# Altium schematic sheet 05_BANK_DDR3.SchDoc
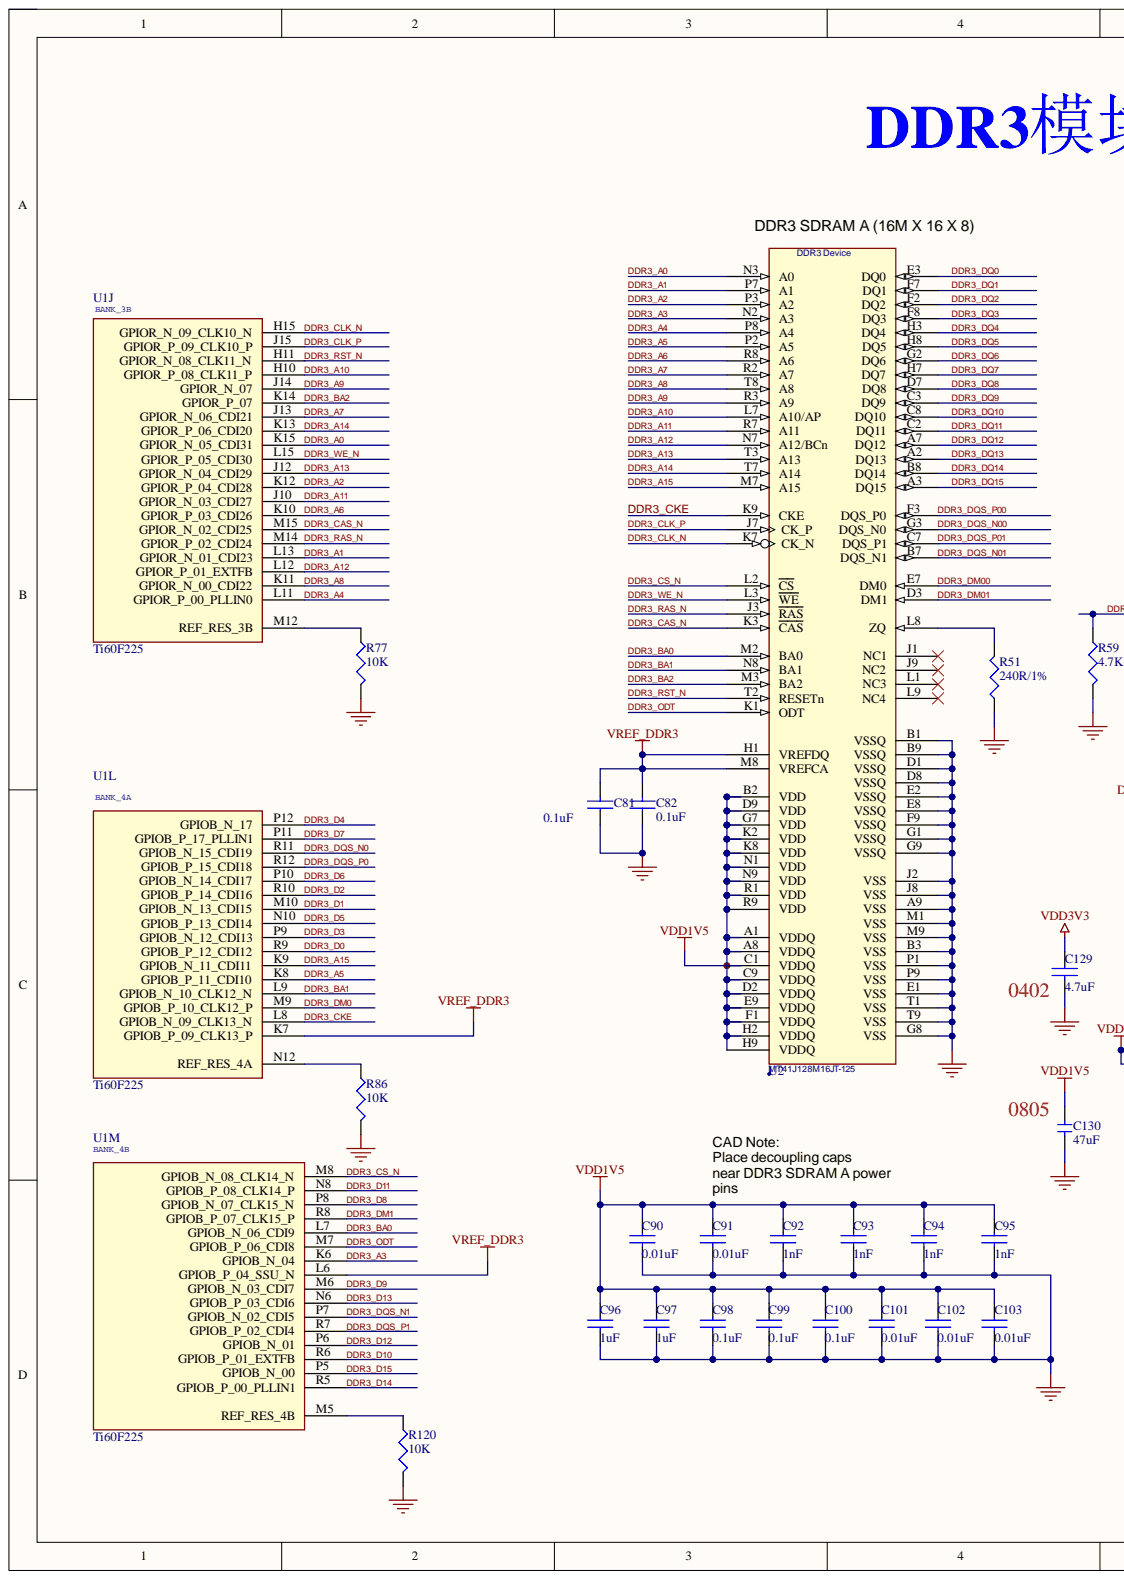
[diagram p0: 05_BANK_DDR3.SchDoc, left]
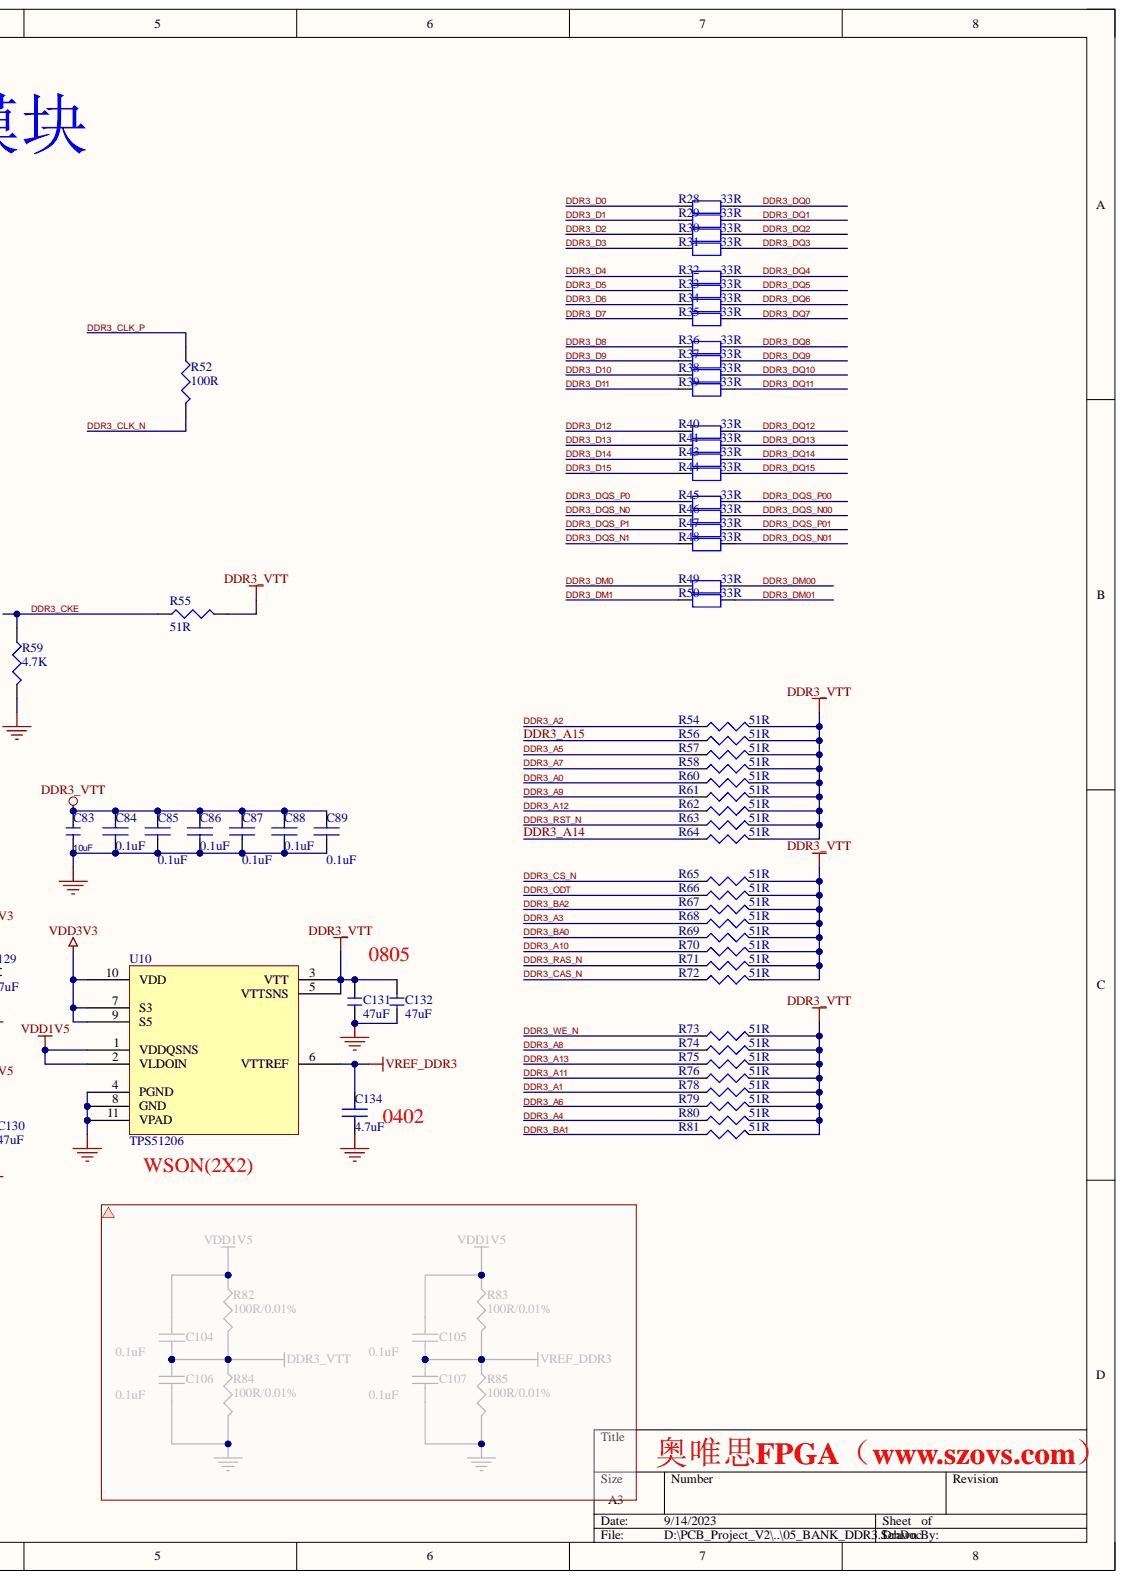
[diagram p1: 05_BANK_DDR3.SchDoc, right]

## Parts
C81  0.1uF  [R0402]
C82  0.1uF  [R0402]
C83  10uF  [R0603]
C84  0.1uF  [R0402]
C85  0.1uF  [R0402]
C86  0.1uF  [R0402]
C87  0.1uF  [R0402]
C88  0.1uF  [R0402]
C89  0.1uF  [R0402]
C90  0.01uF  [R0402]
C91  0.01uF  [R0402]
C92  1nF  [R0402]
C93  1nF  [R0402]
C94  1nF  [R0402]
C95  1nF  [R0402]
C96  1uF  [R0402]
C97  1uF  [R0402]
C98  0.1uF  [R0402]
C99  0.1uF  [R0402]
C100  0.1uF  [R0402]
C101  0.01uF  [R0402]
C102  0.01uF  [R0402]
C103  0.01uF  [R0402]
C129  4.7uF  [R0402]
C130  47uF  [R0805]
C131  47uF  [R0805]
C132  47uF  [R0805]
C134  4.7uF  [R0402]
R28  33R  [R0402]
R29  33R  [R0402]
R30  33R  [R0402]
R31  33R  [R0402]
R32  33R  [R0402]
R33  33R  [R0402]
R34  33R  [R0402]
R35  33R  [R0402]
R36  33R  [R0402]
R37  33R  [R0402]
R38  33R  [R0402]
R39  33R  [R0402]
R40  33R  [R0402]
R41  33R  [R0402]
R43  33R  [R0402]
R44  33R  [R0402]
R45  33R  [R0402]
R46  33R  [R0402]
R47  33R  [R0402]
R48  33R  [R0402]
R49  33R  [R0402]
R50  33R  [R0402]
R51  240R/1%  [R0402]
R52  100R  [R0402]
R54  51R  [R0402]
R55  51R  [R0402]
R56  51R  [R0402]
R57  51R  [R0402]
R58  51R  [R0402]
R59  4.7K  [R0402]
R60  51R  [R0402]
R61  51R  [R0402]
R62  51R  [R0402]
R63  51R  [R0402]
R64  51R  [R0402]
R65  51R  [R0402]
R66  51R  [R0402]
R67  51R  [R0402]
R68  51R  [R0402]
R69  51R  [R0402]
R70  51R  [R0402]
R71  51R  [R0402]
R72  51R  [R0402]
R73  51R  [R0402]
R74  51R  [R0402]
R75  51R  [R0402]
R76  51R  [R0402]
R77  10K  [R0402]
R78  51R  [R0402]
R79  51R  [R0402]
R80  51R  [R0402]
R81  51R  [R0402]
R86  10K  [R0402]
R120  10K  [R0402]
U1  Ti60F225  [ti60F225]  (parts J,L,M)
U2  MT41J128M16JT-125  [FBGA-96_L13.5-W7.5]
U10  TPS51206  [WSON10-040-0202MM]

## Nets
DDR3_VTT: C83.1 C84.1 C85.1 C86.1 C87.1 C88.1 C89.1 C131.1 C132.1 R54.2 R55.2 R56.2 R57.2 R58.2 R60.2 R61.2 R62.2 R63.2 R64.2 R65.2 R66.2 R67.2 R68.2 R69.2 R70.2 R71.2 R72.2 R73.2 R74.2 R75.2 R76.2 R78.2 R79.2 R80.2 R81.2 U10.3(VTT) U10.5(VTTSNS)
GND: C81.1 C82.1 C83.2 C84.2 C85.2 C86.2 C87.2 C88.2 C89.2 C90.2 C91.2 C92.2 C93.2 C94.2 C95.2 C96.2 C97.2 C98.2 C99.2 C100.2 C101.2 C102.2 C103.2 C129.2 C130.2 C131.2 C132.2 C134.2 R51.1 R59.2 R77.2 R86.2 R120.2 U2.A9(VSS) U2.B1(VSSQ) U2.B3(VSS) U2.B9(VSSQ) U2.D1(VSSQ) U2.D8(VSSQ) U2.E1(VSS) U2.E2(VSSQ) U2.E8(VSSQ) U2.F9(VSSQ) U2.G1(VSSQ) U2.G8(VSS) U2.G9(VSSQ) U2.J2(VSS) U2.J8(VSS) U2.M1(VSS) U2.M9(VSS) U2.P1(VSS) U2.P9(VSS) U2.T1(VSS) U2.T9(VSS) U10.4(PGND) U10.8(GND) U10.11(VPAD)
VDD1V5: C90.1 C91.1 C92.1 C93.1 C94.1 C95.1 C96.1 C97.1 C98.1 C99.1 C100.1 C101.1 C102.1 C103.1 C130.1 U2.A1(VDDQ) U2.A8(VDDQ) U2.B2(VDD) U2.C1(VDDQ) U2.C9(VDDQ) U2.D2(VDDQ) U2.D9(VDD) U2.E9(VDDQ) U2.F1(VDDQ) U2.G7(VDD) U2.H2(VDDQ) U2.H9(VDDQ) U2.K2(VDD) U2.K8(VDD) U2.N1(VDD) U2.N9(VDD) U2.R1(VDD) U2.R9(VDD) U10.1(VDDQSNS) U10.2(VLDOIN)
VDD3V3: C129.1 U10.7(S3) U10.9(S5) U10.10(VDD)
VREF_DDR3: C81.2 C82.2 C134.1 U1.K7(GPIOB_P_09_CLK13_P) U1.L6(GPIOB_P_04_SSU_N) U2.H1(VREFDQ) U2.M8(VREFCA) U10.6(VTTREF)
DDR3_A0: R60.1 U1.K15(GPIOR_N_05_CDI31) U2.N3(A0)
DDR3_A1: R78.1 U1.L13(GPIOR_N_01_CDI23) U2.P7(A1)
DDR3_A2: R54.1 U1.K12(GPIOR_P_04_CDI28) U2.P3(A2)
DDR3_A3: R68.1 U1.K6(GPIOB_N_04) U2.N2(A3)
DDR3_A4: R80.1 U1.L11(GPIOR_P_00_PLLIN0) U2.P8(A4)
DDR3_A5: R57.1 U1.K8(GPIOB_P_11_CDI10) U2.P2(A5)
DDR3_A6: R79.1 U1.K10(GPIOR_P_03_CDI26) U2.R8(A6)
DDR3_A7: R58.1 U1.J13(GPIOR_N_06_CDI21) U2.R2(A7)
DDR3_A8: R74.1 U1.K11(GPIOR_N_00_CDI22) U2.T8(A8)
DDR3_A9: R61.1 U1.J14(GPIOR_N_07) U2.R3(A9)
DDR3_A10: R70.1 U1.H10(GPIOR_P_08_CLK11_P) U2.L7(A10/AP)
DDR3_A11: R76.1 U1.J10(GPIOR_N_03_CDI27) U2.R7(A11)
DDR3_A12: R62.1 U1.L12(GPIOR_P_01_EXTFB) U2.N7(A12/BCn)
DDR3_A13: R75.1 U1.J12(GPIOR_N_04_CDI29) U2.T3(A13)
DDR3_A14: R64.1 U1.K13(GPIOR_P_06_CDI20) U2.T7(A14)
DDR3_A15: R56.1 U1.K9(GPIOB_N_11_CDI11) U2.M7(A15)
DDR3_BA0: R69.1 U1.L7(GPIOB_N_06_CDI9) U2.M2(BA0)
DDR3_BA1: R81.1 U1.L9(GPIOB_N_10_CLK12_N) U2.N8(BA1)
DDR3_BA2: R67.1 U1.K14(GPIOR_P_07) U2.M3(BA2)
DDR3_CAS_N: R72.1 U1.M15(GPIOR_N_02_CDI25) U2.K3(CAS)
DDR3_CKE: R55.1 R59.1 U1.L8(GPIOB_N_09_CLK13_N) U2.K9(CKE)
DDR3_CLK_N: R52.2 U1.H15(GPIOR_N_09_CLK10_N) U2.K7(CK_N)
DDR3_CLK_P: R52.1 U1.J15(GPIOR_P_09_CLK10_P) U2.J7(CK_P)
DDR3_CS_N: R65.1 U1.M8(GPIOB_N_08_CLK14_N) U2.L2(CS)
DDR3_D0: R28.1 U1.R9(GPIOB_P_12_CDI12)
DDR3_D1: R29.1 U1.M10(GPIOB_N_13_CDI15)
DDR3_D2: R30.1 U1.R10(GPIOB_P_14_CDI16)
DDR3_D3: R31.1 U1.P9(GPIOB_N_12_CDI13)
DDR3_D4: R32.1 U1.P12(GPIOB_N_17)
DDR3_D5: R33.1 U1.N10(GPIOB_P_13_CDI14)
DDR3_D6: R34.1 U1.P10(GPIOB_N_14_CDI17)
DDR3_D7: R35.1 U1.P11(GPIOB_P_17_PLLIN1)
DDR3_D8: R36.1 U1.P8(GPIOB_N_07_CLK15_N)
DDR3_D9: R37.1 U1.M6(GPIOB_N_03_CDI7)
DDR3_D10: R38.1 U1.R6(GPIOB_P_01_EXTFB)
DDR3_D11: R39.1 U1.N8(GPIOB_P_08_CLK14_P)
DDR3_D12: R40.1 U1.P6(GPIOB_N_01)
DDR3_D13: R41.1 U1.N6(GPIOB_P_03_CDI6)
DDR3_D14: R43.1 U1.R5(GPIOB_P_00_PLLIN1)
DDR3_D15: R44.1 U1.P5(GPIOB_N_00)
DDR3_DM0: R49.1 R49.2 U1.M9(GPIOB_P_10_CLK12_P) U2.E7(DM0)  [also labelled DDR3_DM00]
DDR3_DM1: R50.1 R50.2 U1.R8(GPIOB_P_07_CLK15_P) U2.D3(DM1)  [also labelled DDR3_DM01]
DDR3_DQ0: R28.2 U2.E3(DQ0)
DDR3_DQ1: R29.2 U2.F7(DQ1)
DDR3_DQ2: R30.2 U2.F2(DQ2)
DDR3_DQ3: R31.2 U2.F8(DQ3)
DDR3_DQ4: R32.2 U2.H3(DQ4)
DDR3_DQ5: R33.2 U2.H8(DQ5)
DDR3_DQ6: R34.2 U2.G2(DQ6)
DDR3_DQ7: R35.2 U2.H7(DQ7)
DDR3_DQ8: R36.2 U2.D7(DQ8)
DDR3_DQ9: R37.2 U2.C3(DQ9)
DDR3_DQ10: R38.2 U2.C8(DQ10)
DDR3_DQ11: R39.2 U2.C2(DQ11)
DDR3_DQ12: R40.2 U2.A7(DQ12)
DDR3_DQ13: R41.2 U2.A2(DQ13)
DDR3_DQ14: R43.2 U2.B8(DQ14)
DDR3_DQ15: R44.2 U2.A3(DQ15)
DDR3_DQS_N0: R46.1 R46.2 U1.R11(GPIOB_N_15_CDI19) U2.G3(DQS_N0)  [also labelled DDR3_DQS_N00]
DDR3_DQS_N1: R48.1 R48.2 U1.P7(GPIOB_N_02_CDI5) U2.B7(DQS_N1)  [also labelled DDR3_DQS_N01]
DDR3_DQS_P0: R45.1 R45.2 U1.R12(GPIOB_P_15_CDI18) U2.F3(DQS_P0)  [also labelled DDR3_DQS_P00]
DDR3_DQS_P1: R47.1 R47.2 U1.R7(GPIOB_P_02_CDI4) U2.C7(DQS_P1)  [also labelled DDR3_DQS_P01]
DDR3_ODT: R66.1 U1.M7(GPIOB_P_06_CDI8) U2.K1(ODT)
DDR3_RAS_N: R71.1 U1.M14(GPIOR_P_02_CDI24) U2.J3(RAS)
DDR3_RST_N: R63.1 U1.H11(GPIOR_N_08_CLK11_N) U2.T2(RESETn)
DDR3_WE_N: R73.1 U1.L15(GPIOR_P_05_CDI30) U2.L3(WE)
NetR51_2: R51.2 U2.L8(ZQ)
NetR77_1: R77.1 U1.M12(REF_RES_3B)
NetR86_1: R86.1 U1.N12(REF_RES_4A)
NetR120_1: R120.1 U1.M5(REF_RES_4B)

Not connected: U2 J1(NC1) J9(NC2) L1(NC3) L9(NC4)

## Library data of the parts
C81 C82 C84-C103 C129 C134 = C0402: Component Kind: Standard; Library Name: 162_Library.SchLib
C83 = C0603: Component Kind: Standard; Library Name: 162_Library.SchLib
C130-C132 = C0805: Component Kind: Standard; Library Name: 162_Library.SchLib
R28-R41 R43-R50 = RES: Component Kind: Standard; Library Name: Crazy_PCB.SchLib
R51 R52 R54-R81 R86 R120 = R: Component Kind: Standard; Library Name: 162_Library.SchLib
U1 = Ti60F225: SPLIT_INST: TRUE; SWAP_INFO: (S1+S2+S3+S4+S5+S6+S7+S8+S9+S10+S11+S12+S13); Component Kind: Standard; Library Name: 162_Library.SchLib
U2 = DDR3 SDRAM, MT41J128M16JT-125D: MEM - DRAM, DDR3, 16MX16X8, 2GB, CL11, ON-DIE-TERMINATION, 1.5V CORE, 1.5V IO, BGA-96, LEAD-FREE; Manufacturer: Micron; Manufacturer Part Num: MT41J128M16JT-125:D; Item Num: P14-27603R; Component Kind: Standard; Date: Jun. 08, 2012; Library Name: 162_Library.SchLib; Version: 0.1
U10 = TPS51206: Component Kind: Standard; Library Name: Ti60_F225_Core.SCHLIB
